# Altium schematic sheet Top_COMET_GBTz_DB.SchDoc
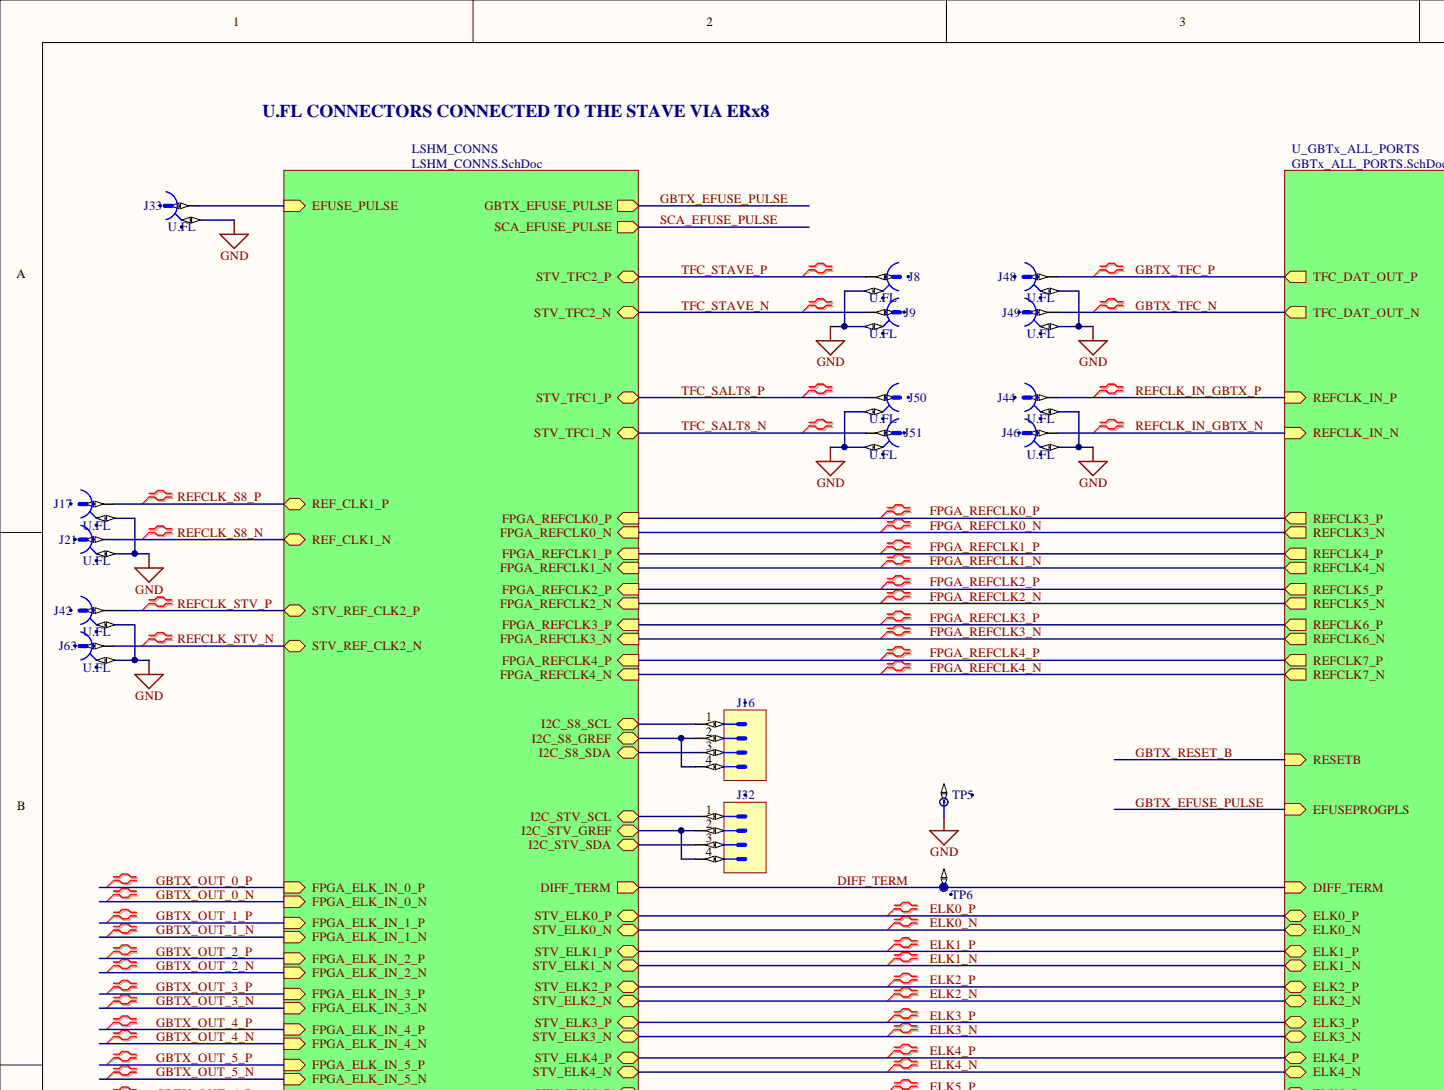
[diagram p0: Top_COMET_GBTz_DB.SchDoc, top left]
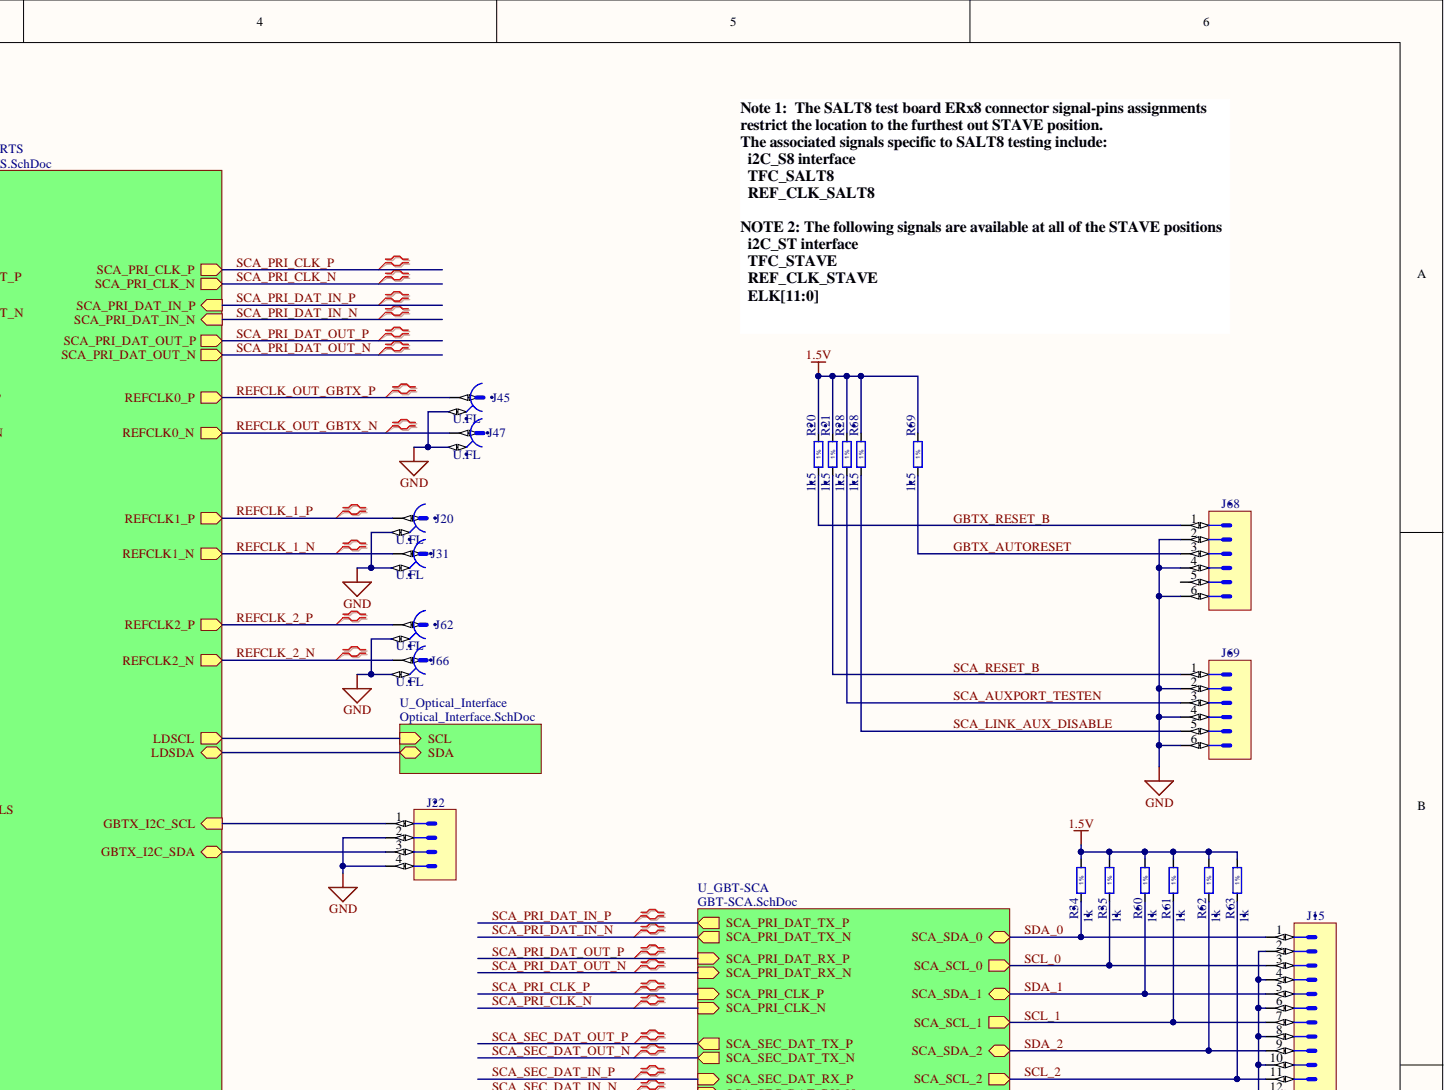
[diagram p1: Top_COMET_GBTz_DB.SchDoc, top right]
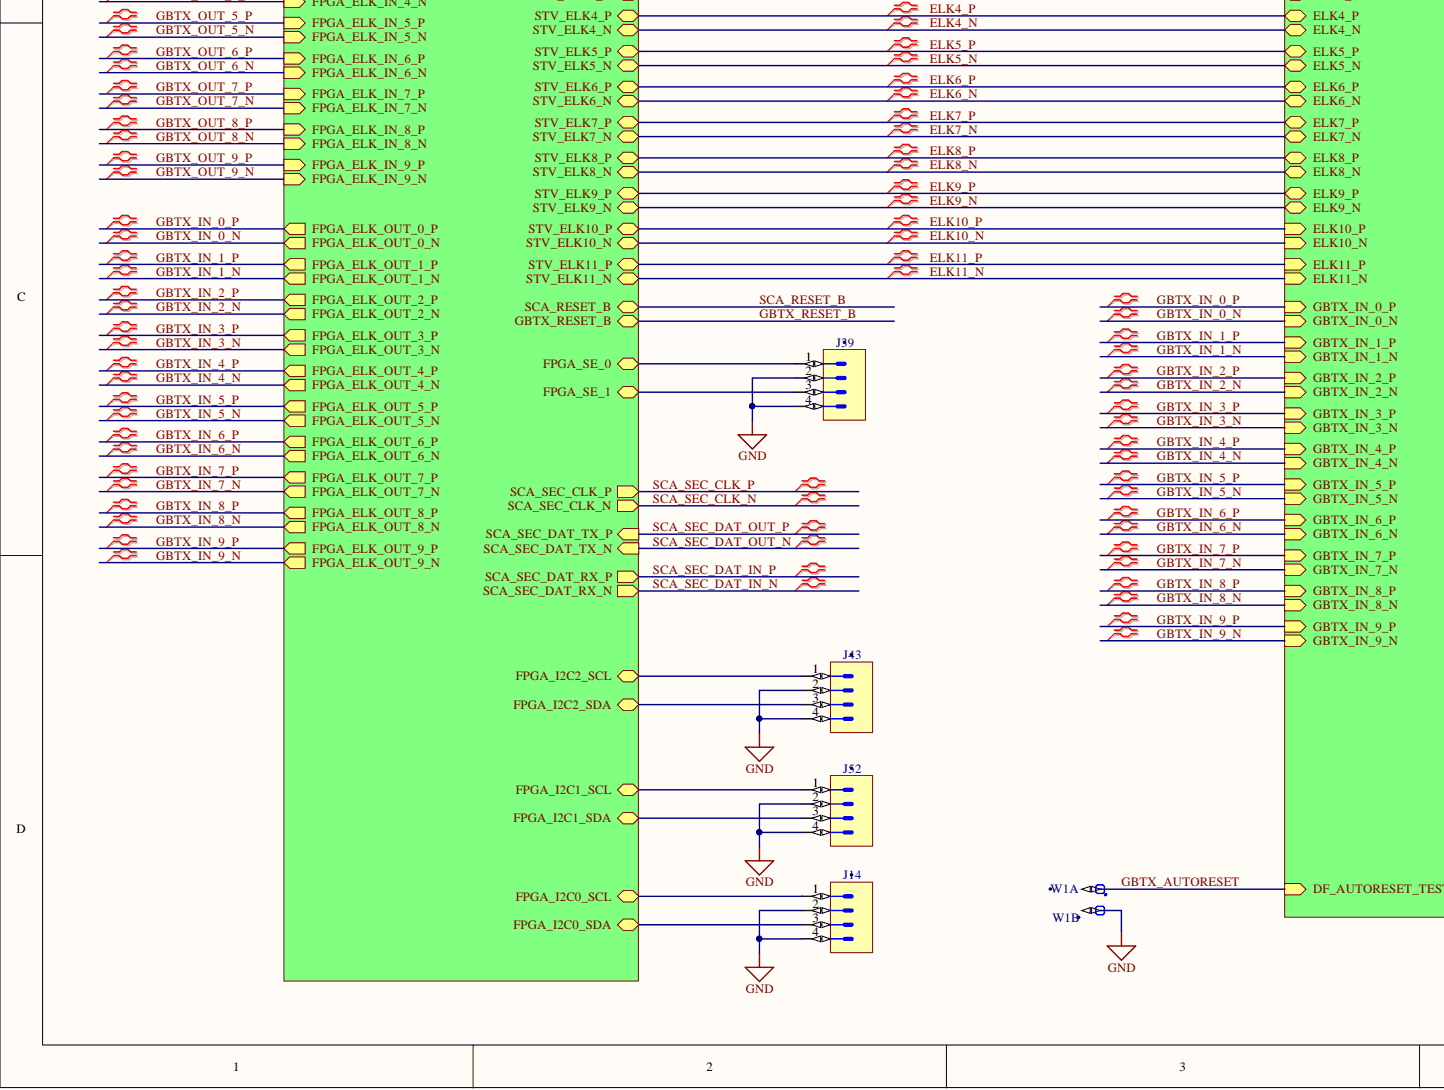
[diagram p2: Top_COMET_GBTz_DB.SchDoc, bottom left]
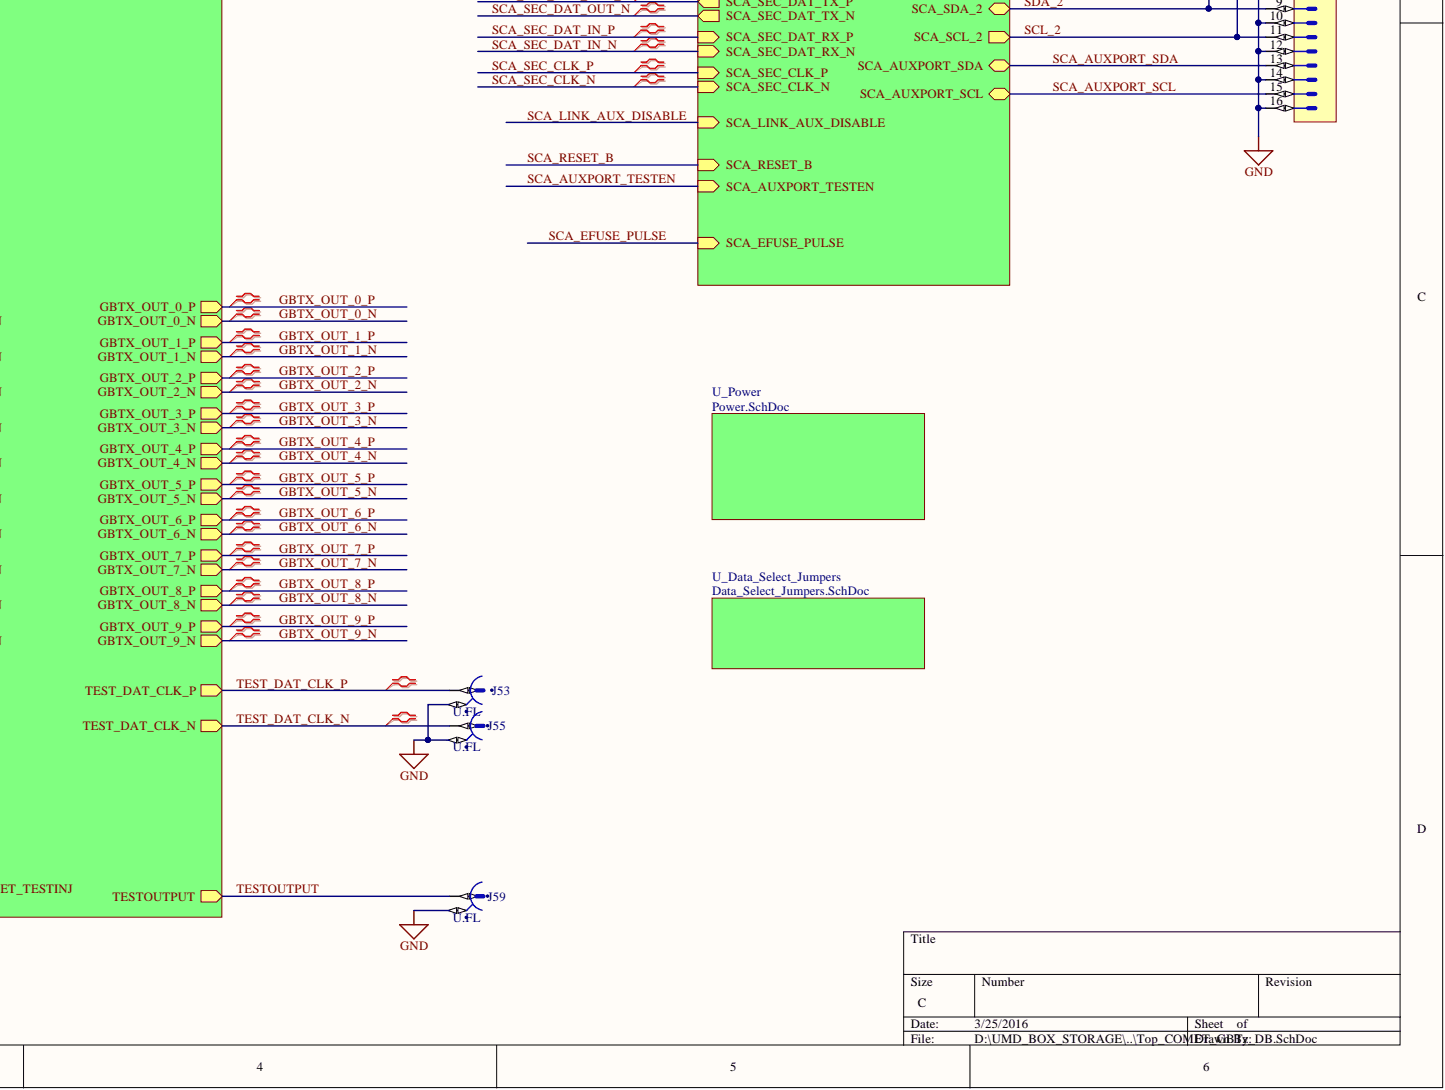
[diagram p3: Top_COMET_GBTz_DB.SchDoc, bottom right]

## Parts
J8  U.FL  [HIROSE_U.FL-R-SMT-1(10)]
J9  U.FL  [HIROSE_U.FL-R-SMT-1(10)]
J14  Header 4  [SAMTEC_MTLW-102-07-L-D-250]  (value not drawn)
J15  Header 16  [SAMTEC_MTLW-108-07-L-D-250]  (value not drawn)
J16  Header 4  [SAMTEC_MTLW-102-07-L-D-250]  (value not drawn)
J17  U.FL  [HIROSE_U.FL-R-SMT-1(10)]
J20  U.FL  [HIROSE_U.FL-R-SMT-1(10)]
J21  U.FL  [HIROSE_U.FL-R-SMT-1(10)]
J22  Header 4  [SAMTEC_MTLW-102-07-L-D-250]  (value not drawn)
J31  U.FL  [HIROSE_U.FL-R-SMT-1(10)]
J32  Header 4  [SAMTEC_MTLW-102-07-L-D-250]  (value not drawn)
J33  U.FL  [HIROSE_U.FL-R-SMT-1(10)]
J39  Header 4  [SAMTEC_MTLW-102-07-L-D-250]  (value not drawn)
J42  U.FL  [HIROSE_U.FL-R-SMT-1(10)]
J43  Header 4  [SAMTEC_MTLW-102-07-L-D-250]  (value not drawn)
J44  U.FL  [HIROSE_U.FL-R-SMT-1(10)]
J45  U.FL  [HIROSE_U.FL-R-SMT-1(10)]
J46  U.FL  [HIROSE_U.FL-R-SMT-1(10)]
J47  U.FL  [HIROSE_U.FL-R-SMT-1(10)]
J48  U.FL  [HIROSE_U.FL-R-SMT-1(10)]
J49  U.FL  [HIROSE_U.FL-R-SMT-1(10)]
J50  U.FL  [HIROSE_U.FL-R-SMT-1(10)]
J51  U.FL  [HIROSE_U.FL-R-SMT-1(10)]
J52  Header 4  [SAMTEC_MTLW-102-07-L-D-250]  (value not drawn)
J53  U.FL  [HIROSE_U.FL-R-SMT-1(10)]
J55  U.FL  [HIROSE_U.FL-R-SMT-1(10)]
J59  U.FL  [HIROSE_U.FL-R-SMT-1(10)]
J62  U.FL  [HIROSE_U.FL-R-SMT-1(10)]
J63  U.FL  [HIROSE_U.FL-R-SMT-1(10)]
J66  U.FL  [HIROSE_U.FL-R-SMT-1(10)]
J68  Header 6  [SULLINS_GRPB032VWVN-RC]  (value not drawn)
J69  Header 6  [SULLINS_GRPB032VWVN-RC]  (value not drawn)
R20  1k5  [RESC1005X40N]
R21  1k5  [RESC1005X40N]
R28  1k5  [RESC1005X40N]
R34  1k  [RESC1005X40N]
R35  1k  [RESC1005X40N]
R60  1k  [RESC1005X40N]
R61  1k  [RESC1005X40N]
R62  1k  [RESC1005X40N]
R63  1k  [RESC1005X40N]
R68  1k5  [RESC1005X40N]
R69  1k5  [RESC1005X40N]
TP5  Family  [TYCO_RCT-0C]  (value not drawn)
TP6  Family  [TYCO_RCT-0C]  (value not drawn)
W1  Header 2  [SAMTEC_TSW-102-23-L-S]  (value not drawn)  (parts A,B)

## Nets
1.5V: R20.2 R21.2 R28.2 R34.2 R35.2 R60.2 R61.2 R62.2 R63.2 R68.2 R69.2
GND: J8.2 J9.2 J14.2 J14.4 J15.2 J15.4 J15.6 J15.8 J15.10 J15.12 J15.14 J15.16 J17.2 J20.2 J21.2 J22.2 J22.4 J31.2 J33.2 J39.2 J39.4 J42.2 J43.2 J43.4 J44.2 J45.2 J46.2 J47.2 J48.2 J49.2 J50.2 J51.2 J52.2 J52.4 J53.2 J55.2 J59.2 J62.2 J63.2 J66.2 J68.2 J68.4 J68.6 J69.2 J69.4 J69.6 TP5.1 W1.2
DIFF_TERM: TP6.1
GBTX_AUTORESET: J68.3 R69.1 W1.1
GBTX_RESET_B: J68.1 R20.1
GBTX_TFC_N: J49.1
GBTX_TFC_P: J48.1
REFCLK_1_N: J31.1
REFCLK_1_P: J20.1
REFCLK_2_N: J66.1
REFCLK_2_P: J62.1
REFCLK_IN_GBTX_N: J46.1
REFCLK_IN_GBTX_P: J44.1
REFCLK_OUT_GBTX_N: J47.1
REFCLK_OUT_GBTX_P: J45.1
REFCLK_S8_N: J21.1
REFCLK_S8_P: J17.1
REFCLK_STV_N: J63.1
REFCLK_STV_P: J42.1
SCA_AUXPORT_SCL: J15.15
SCA_AUXPORT_SDA: J15.13
SCA_AUXPORT_TESTEN: J69.3 R28.1
SCA_LINK_AUX_DISABLE: J69.5 R68.1
SCA_RESET_B: J69.1 R21.1
SCL_0: J15.3 R35.1
SCL_1: J15.7 R61.1
SCL_2: J15.11 R63.1
SDA_0: J15.1 R34.1
SDA_1: J15.5 R60.1
SDA_2: J15.9 R62.1
TEST_DAT_CLK_N: J55.1
TEST_DAT_CLK_P: J53.1
TESTOUTPUT: J59.1
TFC_SALT8_N: J51.1
TFC_SALT8_P: J50.1
TFC_STAVE_N: J9.1
TFC_STAVE_P: J8.1
NetJ14_1: J14.1
NetJ14_3: J14.3
NetJ16_1: J16.1
NetJ16_2: J16.2 J16.4
NetJ16_3: J16.3
NetJ22_1: J22.1
NetJ22_3: J22.3
NetJ32_1: J32.1
NetJ32_2: J32.2 J32.4
NetJ32_3: J32.3
NetJ33_1: J33.1
NetJ39_1: J39.1
NetJ39_3: J39.3
NetJ43_1: J43.1
NetJ43_3: J43.3
NetJ52_1: J52.1
NetJ52_3: J52.3
NetJ68_5: J68.5
ELK0_N:
ELK0_P:
ELK1_N:
ELK1_P:
ELK2_N:
ELK2_P:
ELK3_N:
ELK3_P:
ELK4_N:
ELK4_P:
ELK5_N:
ELK5_P:
ELK6_N:
ELK6_P:
ELK7_N:
ELK7_P:
ELK8_N:
ELK8_P:
ELK9_N:
ELK9_P:
ELK10_N:
ELK10_P:
ELK11_N:
ELK11_P:
FPGA_REFCLK0_N:
FPGA_REFCLK0_P:
FPGA_REFCLK1_N:
FPGA_REFCLK1_P:
FPGA_REFCLK2_N:
FPGA_REFCLK2_P:
FPGA_REFCLK3_N:
FPGA_REFCLK3_P:
FPGA_REFCLK4_N:
FPGA_REFCLK4_P:
GBTX_EFUSE_PULSE:
GBTX_IN_0_N:
GBTX_IN_0_P:
GBTX_IN_1_N:
GBTX_IN_1_P:
GBTX_IN_2_N:
GBTX_IN_2_P:
GBTX_IN_3_N:
GBTX_IN_3_P:
GBTX_IN_4_N:
GBTX_IN_4_P:
GBTX_IN_5_N:
GBTX_IN_5_P:
GBTX_IN_6_N:
GBTX_IN_6_P:
GBTX_IN_7_N:
GBTX_IN_7_P:
GBTX_IN_8_N:
GBTX_IN_8_P:
GBTX_IN_9_N:
GBTX_IN_9_P:
GBTX_OUT_0_N:
GBTX_OUT_0_P:
GBTX_OUT_1_N:
GBTX_OUT_1_P:
GBTX_OUT_2_N:
GBTX_OUT_2_P:
GBTX_OUT_3_N:
GBTX_OUT_3_P:
GBTX_OUT_4_N:
GBTX_OUT_4_P:
GBTX_OUT_5_N:
GBTX_OUT_5_P:
GBTX_OUT_6_N:
GBTX_OUT_6_P:
GBTX_OUT_7_N:
GBTX_OUT_7_P:
GBTX_OUT_8_N:
GBTX_OUT_8_P:
GBTX_OUT_9_N:
GBTX_OUT_9_P:
N00424:
N00425:
SCA_EFUSE_PULSE:
SCA_PRI_CLK_N:
SCA_PRI_CLK_P:
SCA_PRI_DAT_IN_N:
SCA_PRI_DAT_IN_P:
SCA_PRI_DAT_OUT_N:
SCA_PRI_DAT_OUT_P:
SCA_SEC_CLK_N:
SCA_SEC_CLK_P:
SCA_SEC_DAT_IN_N:
SCA_SEC_DAT_IN_P:
SCA_SEC_DAT_OUT_N:
SCA_SEC_DAT_OUT_P:

## Library data of the parts
J8 J9 J17 J20 J21 J31 J33 J42 J44-J51 J53 J55 J59 J62 J63 J66 = HIROSE_U.FL-R-SMT-1(10): Manufacturer: HIROSE (HRS); Part Description: Up to 6GHz Ultra Small Surface Mount Male Coaxial Connectors U.FL Series; Database Table Name: Miscellaneous; PressFit: No; ComponentHeight: 1.25mm; Component Kind: Standard; Component Type: Standard; CreateDate: 3/24/2010; Family: U.FL; HelpURL: \\cern.ch\dfs\Applications\Altium\Datasheets\HIROSE_U.FL-R-SMT-1(10).pdf; LatestRevisionDate: 3/24/2010; Library Name: Connectors.DbLib; Manufacturer Part Number: U.FL-R-SMT-1(10); Mounted: Yes; Part Number: HIROSE_U.FL-R-SMT-1(10); Pin Count: 2; Sense: No; SMD: Yes; Socket: No; Status: None; Author: CERN DEM JLC
J14 J16 J22 J32 J39 J43 J52 = SAMTEC_MTLW-102-07-L-D-250: Connector 4 Male; Part Description: 4 Contacts - Pitch 2.54mm - Dual Row Through Mount Straight Header (Post Lead = 6.35mm, Tail Lead 3.05mm, Insulator 1.52mm); Database Table Name: SAMTEC; PressFit: No; ComponentHeight: 7.87mm; Component Kind: Standard; Component Type: Standard; CreateDate: 12/13/2012; Family: Header 4; HelpURL: \\cern.ch\dfs\Applications\Altium\Datasheets\SAMTEC_MTLW-102-07-L-D-250.pdf; LatestRevisionDate: 12/13/2012; Library Name: Connectors.DbLib; Manufacturer: SAMTEC; Manufacturer Part Number: MTLW-102-07-L-D-250; Mounted: Yes; Part Number: SAMTEC_MTLW-102-07-L-D-250; Pin Count: 4; Sense: No; SMD: No; Socket: No; Status: None; Author: CERN DEM JLC
J15 = SAMTEC_MTLW-108-07-L-D-250: Connector 16 Male; Part Description: 16 Contacts - Pitch 2.54mm - Dual Row Through Mount Straight Header (Post Lead = 6.35mm, Tail Lead 3.05mm, Insulator 1.52mm); Database Table Name: SAMTEC; PressFit: No; ComponentHeight: 7.87mm; Component Kind: Standard; Component Type: Standard; CreateDate: 4/11/2013; Family: Header 16; HelpURL: \\cern.ch\dfs\Applications\Altium\Datasheets\SAMTEC_MTLW-108-07-L-D-250.pdf; LatestRevisionDate: 4/11/2013; Library Name: Connectors.DbLib; Manufacturer: SAMTEC; Manufacturer Part Number: MTLW-108-07-L-D-250; Mounted: Yes; Part Number: SAMTEC_MTLW-108-07-L-D-250; Pin Count: 16; Sense: No; SMD: No; Socket: No; Status: None; Author: CERN DEM JLC
J68 J69 = SULLINS_GRPB032VWVN-RC: Connector 6 Male; Manufacturer: SULLINS CONNECTOR SOLUTIONS; Part Description: 6 Contacts - Pitch 1.27mm - Dual Row Straight Strip Header - Insulator 1mm; Database Table Name: Miscellaneous; PressFit: No; ComponentHeight: 4mm; Component Kind: Standard; Component Type: Standard; CreateDate: 11/1/2012; Family: Header 6; HelpURL: \\cern.ch\dfs\Applications\Altium\Datasheets\SULLINS_GRPB032VWVN-RC.pdf; LatestRevisionDate: 11/1/2012; Library Name: Connectors.DbLib; Manufacturer Part Number: GRPB032VWVN-RC; Mounted: Yes; Part Number: SULLINS_GRPB032VWVN-RC; Pin Count: 6; Sense: No; SMD: No; Socket: No; Status: None; Author: CERN DEM JLC
R20 R21 R28 R68 R69 = R0402_1K5_1%_0.0625W_100PPM: Resistor - 1%; Manufacturer: GENERIC; Manufacturer1 Part Number: 232270671502L; Part Description: General Purpose Thick Film Chip Resistor; Database Table Name: Resistors SMD; TC: ±100ppm/°C; Case: 0402; Component Kind: Standard; Component Type: Standard; CreateDate: 12/3/2007; HelpURL: \\cern.ch\dfs\Applications\Altium\Datasheets\R0402_Phycomp_RC0402.pdf; LatestRevisionDate: 10/17/2012; Library Name: Resistors.DbLib; Manufacturer1 ComponentHeight: 0.4mm; Manufacturer1 Example: YAGEO PHYCOMP; Manufacturer Part Number: R0402_1K5_1%_0.0625W_100PPM; Mounted: Yes; Part Number: R0402_1K5_1%_0.0625W_100PPM; Pin Count: 2; Power: 0.0625W; Sense: No; SMD: Yes; Socket: No; Status: Not recommended; Tolerance: ±1%; Value: 1k5; Author: CERN DEM JLC
R34 R35 R60-R63 = R0402_1K_1%_0.0625W_100PPM: Resistor - 1%; Manufacturer: GENERIC; Manufacturer1 Part Number: 232270671002L; Part Description: General Purpose Thick Film Chip Resistor; Database Table Name: Resistors SMD; TC: ±100ppm/°C; Case: 0402; Component Kind: Standard; Component Type: Standard; CreateDate: 12/3/2007; HelpURL: \\cern.ch\dfs\Applications\Altium\Datasheets\R0402_Phycomp_RC0402.pdf; LatestRevisionDate: 10/17/2012; Library Name: Resistors.DbLib; Manufacturer1 ComponentHeight: 0.4mm; Manufacturer1 Example: YAGEO PHYCOMP; Manufacturer Part Number: R0402_1K_1%_0.0625W_100PPM; Mounted: Yes; Part Number: R0402_1K_1%_0.0625W_100PPM; Pin Count: 2; Power: 0.0625W; Sense: No; SMD: Yes; Socket: No; Status: Not recommended; Tolerance: ±1%; Value: 1k; Author: CERN DEM JLC
TP5 TP6 = TYCO_RCT-0C: Test Point; Manufacturer: TYCO ELECTRONICS; Manufacturer Part Number: RCT-0C; Part Description: 0805 (2012) Surface Mount Test Point; Database Table Name: TYCO; PressFit: No; ComponentHeight: 1.45mm; Component Kind: Standard; Component Type: Standard; CreateDate: 5/7/2014; HelpURL: \\cern.ch\dfs\Applications\Altium\Datasheets\TYCO_RCT-0C.pdf; LatestRevisionDate: 5/7/2014; Library Name: Connectors.DbLib; Mounted: Yes; Part Number: TYCO_RCT-0C; Pin Count: 1; Sense: No; SMD: Yes; Socket: No; Status: None; Author: CERN DEM JLC
W1 = SAMTEC_TSW-102-23-L-S: 2 Mini Wire-Wrap Contact Strip Header; Part Description: 2 Contacts - Pitch 2.54mm - Single Row  Through Mount Straight Header (Post Lead 5.84mm, Tail Lead 2.92mm, Insulator 2.54mm); Database Table Name: SAMTEC; PressFit: No; ComponentHeight: 8.38mm; Component Kind: Standard; Component Type: Standard; CreateDate: 10/10/2012; Family: Header 2; HelpURL: \\cern.ch\dfs\Applications\Altium\Datasheets\SAMTEC_TSW-102-23-L-S.pdf; LatestRevisionDate: 10/10/2012; Library Name: Connectors.DbLib; Manufacturer: SAMTEC; Manufacturer Part Number: TSW-102-23-L-S; Mounted: Yes; Part Number: SAMTEC_TSW-102-23-L-S; Pin Count: 2; Sense: No; SMD: No; Socket: No; Status: None; Author: CERN DEM JLC
